# Altium schematic sheet sensors.SchDoc(sensors)
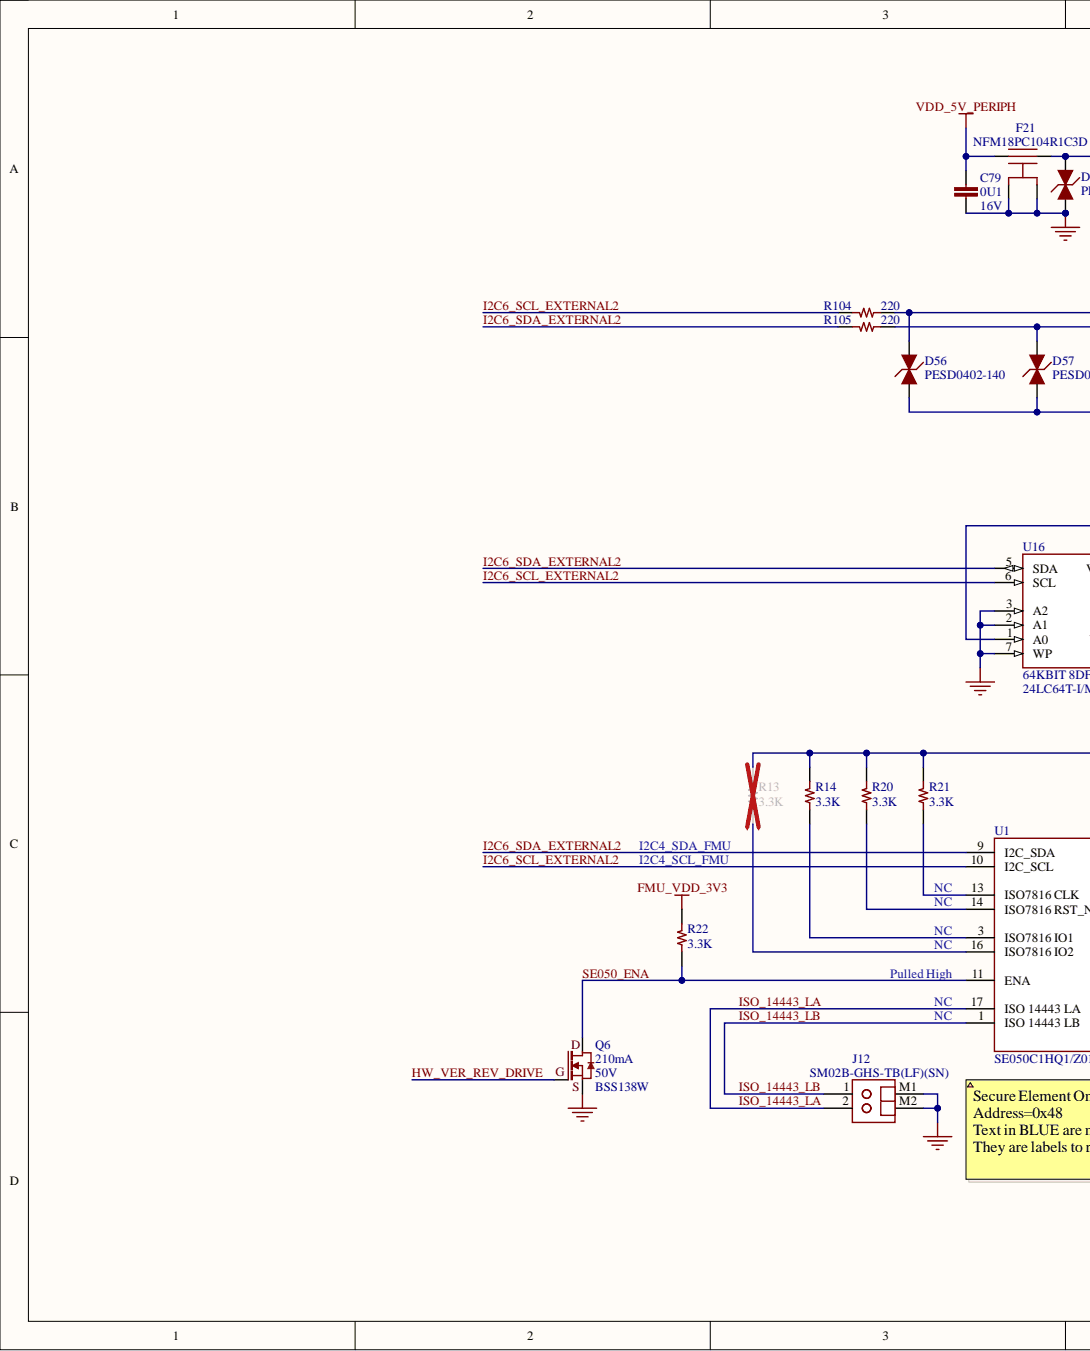
[diagram p0: sensors.SchDoc, left]
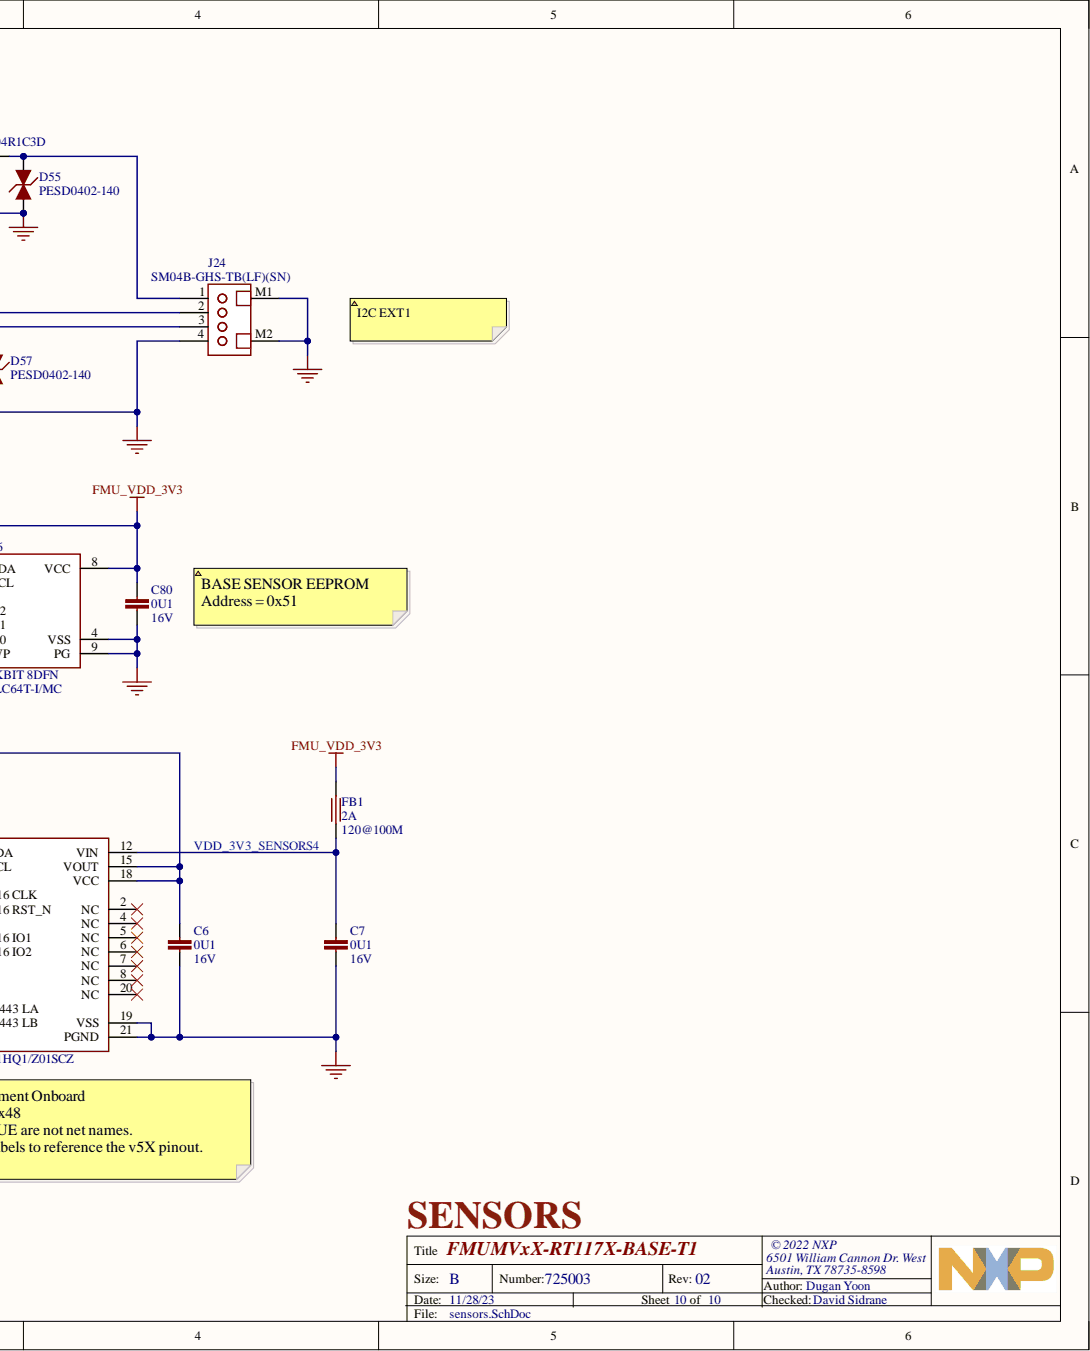
[diagram p1: sensors.SchDoc, right]

## Parts
C6  value 0U1  Voltage - Rated: 16V  [CAPC100X50X56L25N]  (hidden comment: CAP CER 0.1UF 10% 16V X7R 0402)
C7  value 0U1  Voltage - Rated: 16V  [CAPC100X50X56L25N]  (hidden comment: CAP CER 0.1UF 10% 16V X7R 0402)
C79  value 0U1  Voltage - Rated: 16V  [CAPC100X50X56L25N]  (hidden comment: CAP CER 0.1UF 10% 16V X7R 0402)
C80  value 0U1  Voltage - Rated: 16V  [CAPC100X50X56L25N]  (hidden comment: CAP CER 0.1UF 10% 16V X7R 0402)
D55  Manufacturer Part Number: PESD0402-140  [DIONC100X50X43L20N]  (hidden comment: DIODE TVS BIDIRECTIONAL 14VWM 40VC 0402)
D56  Manufacturer Part Number: PESD0402-140  [DIONC100X50X43L20N]  (hidden comment: DIODE TVS BIDIRECTIONAL 14VWM 40VC 0402)
D57  Manufacturer Part Number: PESD0402-140  [DIONC100X50X43L20N]  (hidden comment: DIODE TVS BIDIRECTIONAL 14VWM 40VC 0402)
F21  Manufacturer Part Number: NFM18PC104R1C3D  Voltage - Rated: 16V  [NFM18PC104R1C3_0603_NO_VIA]  (hidden comment: FILTER CAP FEEDTHRU 0.1UF 20% 16V 0603)
FB1  value 120@100M  Current: 2A  [BEADC100X50X55L25]  (hidden comment: IND FERRITE 120@100M 2A 0402)
J12  Manufacturer Part Number: SM02B-GHS-TB(LF)(SN)  [JST_SM02B-GHS-TBLFSN]  (hidden comment: CONN HEADER GH SIDE 2POS 1.25MM SMT)
J24  Manufacturer Part Number: SM04B-GHS-TB(LF)(SN)  [JST_SM04B-GHS-TBLFSN]  (hidden comment: CONN HEADER GH SIDE 4POS 1.25MM SMT)
Q6  Current: 210mA  Manufacturer Part Number: BSS138W  Voltage: 50V  [OnSemi_SC-70-3P65_210X110L20X32N]  (hidden comment: TRANSISTOR N-CH 50V .21A  SC-70)
R13  value 3.3K  [RESC100X50X40L25]  (hidden comment: RES)
R14  value 3.3K  [RESC100X50X40L25]  (hidden comment: RES)
R20  value 3.3K  [RESC100X50X40L25]  (hidden comment: RES)
R21  value 3.3K  [RESC100X50X40L25]  (hidden comment: RES)
R22  value 3.3K  [RESC100X50X40L25]  (hidden comment: RES)
R104  value 220  [RESC100X50X40L25]  (hidden comment: RES SMD 220 OHM 1% 1/16W 0402)
R105  value 220  [RESC100X50X40L25]  (hidden comment: RES SMD 220 OHM 1% 1/16W 0402)
U1  Manufacturer Part Number: SE050C1HQ1/Z01SCZ  [QFN21P40_300X300X33L30X20T190]  (hidden comment: IC IoT Secure Element 20-HX2QFN)
U16  ID: 64KBIT 8DFN  Manufacturer Part Number: 24LC64T-I/MC  [SON9P50_200X300X100L40X25T153X170N]  (hidden comment: IC EEPROM 64K I2C 400KHZ 8DFN)

## Nets
FMU_VDD_3V3: C80.1 FB1.1 R22.1 U16.1(A0) U16.8(VCC)
GND: C6.2 C7.2 C79.2 C80.2 D55.1 D56.1 D57.1 F21.2A F21.2B J12.M1 J12.M2 J24.4 J24.M1 J24.M2 Q6.S U1.19(VSS) U1.21(PGND) U16.2(A1) U16.3(A2) U16.4(VSS) U16.7(WP) U16.9(PG)
VDD_5V_PERIPH: C79.1 F21.1
HW_VER_REV_DRIVE: Q6.G
I2C6_SCL_EXTERNAL2: R104.1 U1.10(I2C_SCL) U16.6(SCL)
I2C6_SDA_EXTERNAL2: R105.1 U1.9(I2C_SDA) U16.5(SDA)
ISO_14443_LA: J12.2 U1.17(ISO_14443_LA)
ISO_14443_LB: J12.1 U1.1(ISO_14443_LB)
SE050_ENA: Q6.D R22.2 U1.11(ENA)
NetC6_1: C6.1 R13.1 R14.1 R20.1 R21.1 U1.15(VOUT) U1.18(VCC)
NetC7_1: C7.1 FB1.2 U1.12(VIN)
NetD55_2: D55.2 F21.3 J24.1
NetD56_2: D56.2 J24.2 R104.2
NetD57_2: D57.2 J24.3 R105.2
NetR13_2: R13.2 U1.16(ISO7816_IO2)
NetR14_2: R14.2 U1.3(ISO7816_IO1)
NetR20_2: R20.2 U1.14
NetR21_2: R21.2 U1.13(ISO7816_CLK)

Not connected: U1 2(NC) 4(NC) 5(NC) 6(NC) 7(NC) 8(NC) 20(NC)

## Library data of the parts
C6 C7 C79 C80 = CAP_0U1_16V_X7R_0402: Manufacturer: Murata Electronics; Manufacturer Part Number: GCM155R71C104KA55J; Category: Capacitors; Component Kind: Standard; ComponentLink1Description: https://www.digikey.com/product-detail/en/murata-electronics/GCM155R71C104KA55J/490-7998-1-ND/4380283; ComponentLink1URL: https://www.digikey.com/product-detail/en/murata-electronics/GCM155R71C104KA55J/490-7998-1-ND/4380283; Description: CAP CER 0.1UF 10% 16V X7R 0402; Operating Temperature: -55°C ~ 125°C; Packaging: Cut Tape (CT); Part Status: Active; Pricing 1: 1=0.1, 10=0.049, 100=0.022, 500=0.0157, 1000=0.01233, 2500=0.01121, 5000=0.01032 (USD); Stock 1: 6381653; Supplier 1: Digi-Key; Supplier Part Number 1: 490-7998-1-ND; Tolerance: ±10%; Library Name: NscDG_Integrated_Library.SchLib
D55-D57 = DIODE_TVS_0402: Manufacturer: Littelfuse Inc.; Category: Circuit Protection; Component Kind: Standard; ComponentLink1Description: https://www.digikey.com/products/en/circuit-protection/tvs-diodes/144?k=PESD0402-140&k=&pkeyword=PESD0402-140&pv7=2&sf=0&quantity=&ColumnSort=0&page=1&pageSize=25; ComponentLink1URL: https://www.digikey.com/products/en/circuit-protection/tvs-diodes/144?k=PESD0402-140&k=&pkeyword=PESD0402-140&pv7=2&sf=0&quantity=&ColumnSort=0&page=1&pageSize=25; Description: DIODE TVS BIDIRECTIONAL 14VWM 40VC 0402; Operating Temperature: -55°C ~ 125°C (TJ); Packaging: Cut Tape (CT); Part Status: Active; Pricing 1: 10000=0.13154, 30000=0.12096 (USD); Stock 1: 281442; Supplier 1: Digi-Key; Supplier Part Number 1: PESD0402-140CT-ND; Library Name: NscDG_Integrated_Library.SchLib
F21 = FILTER_0.1uF_16V_2A_NO_VIA_0603: Manufacturer: Murata Electronics North America; Category: Filters; Component Kind: Standard; ComponentLink1Description: https://www.digikey.com/products/en/filters/feed-through-capacitors/845?k=NFM18PC104R1C3D&k=&pkeyword=NFM18PC104R1C3D&pv7=2&sf=0&quantity=&ColumnSort=0&page=1&pageSize=25; ComponentLink1URL: https://www.digikey.com/products/en/filters/feed-through-capacitors/845?k=NFM18PC104R1C3D&k=&pkeyword=NFM18PC104R1C3D&pv7=2&sf=0&quantity=&ColumnSort=0&page=1&pageSize=25; Description: FILTER CAP FEEDTHRU 0.1UF 20% 16V 0603; Operating Temperature: -55°C ~ 125°C; Packaging: Cut Tape (CT); Part Status: Active; Pricing 1: 1=0.19, 10=0.173, 25=0.1652, 50=0.1598, 100=0.1544, 250=0.1464, 500=0.12246, 1000=0.09503 (USD); Stock 1: 172860; Supplier 1: Digi-Key; Supplier Part Number 1: 490-6969-1-ND; Tolerance: ±20%; Library Name: NscDG_Integrated_Library.SchLib
FB1 = IND_FERRITE_120@120M_2A_0402: Manufacturer: Murata Electronics North America; Manufacturer Part Number: BLM15PX121SN1D; Category: Inductors; Component Kind: Standard; ComponentLink1Description: https://www.digikey.com/product-detail/en/murata-electronics/BLM15PX121SN1D/490-9656-1-ND/4934752; ComponentLink1URL: https://www.digikey.com/product-detail/en/murata-electronics/BLM15PX121SN1D/490-9656-1-ND/4934752; Description: IND FERRITE 120@100M 2A 0402; Operating Temperature: -55°C ~ 125°C; Packaging: Cut Tape (CT); Part Status: Active; Pricing 1: 1=0.10 (USD); Stock 1: 98122; Supplier 1: Digi-Key; Supplier Part Number 1: 490-9656-1-ND; Library Name: NscDG_Integrated_Library.SchLib
J12 = CONN_JST_GH_2PIN_RA_SMT: Manufacturer: JST Sales America Inc.; System: Connector; Voltage - Rated: 50V; Category: Connectors, Interconnects; Component Kind: Standard; ComponentLink1Description: https://www.digikey.com/products/en/connectors-interconnects/rectangular-connectors-headers-male-pins/314?k=sm02b&k=&pkeyword=sm02b&sv=0&pv7=2&s=2395&pv1989=0&sf=0&FV=ffe0013a&quantity=&ColumnSort=0&page=1&pageSize=25; ComponentLink1URL: https://www.digikey.com/products/en/connectors-interconnects/rectangular-connectors-headers-male-pins/314?k=sm02b&k=&pkeyword=sm02b&sv=0&pv7=2&s=2395&pv1989=0&sf=0&FV=ffe0013a&quantity=&ColumnSort=0&page=1&pageSize=25; Description: CONN HEADER GH SIDE 2POS 1.25MM SMT; Operating Temperature: -25°C ~ 85°C; Packaging: Cut Tape (CT); Part Status: Active; Pricing 1: 1=0.44, 10=0.413, 100=0.316, 500=0.27502, 1000=0.22689 (USD); Stock 1: 90823; Supplier 1: Digi-Key; Supplier Part Number 1: 455-1564-1-ND; Library Name: NscDG_Integrated_Library.SchLib
J24 = CONN_JST_GH_4PIN_RA_SMT: Manufacturer: JST Sales America Inc.; System: Connector; Voltage Rating: 50V; Category: Connectors, Interconnects; Component Kind: Standard; ComponentLink1Description: https://www.digikey.com/products/en/connectors-interconnects/rectangular-connectors-headers-male-pins/314?k=SM04B-GHS-TB%28LF%29%28SN%29-&k=&pkeyword=SM04B-GHS-TB%28LF%29%28SN%29-&pv7=2&sf=0&quantity=&ColumnSort=0&page=1&pageSize=25; ComponentLink1URL: https://www.digikey.com/products/en/connectors-interconnects/rectangular-connectors-headers-male-pins/314?k=SM04B-GHS-TB%28LF%29%28SN%29-&k=&pkeyword=SM04B-GHS-TB%28LF%29%28SN%29-&pv7=2&sf=0&quantity=&ColumnSort=0&page=1&pageSize=25; Description: CONN HEADER GH SIDE 4POS 1.25MM SMT; Operating Temperature: -25°C ~ 85°C; Packaging: Cut Tape (CT); Part Status: Active; Pricing 1: 1=0.45, 10=0.423, 100=0.3244, 500=0.28212, 1000=0.23274 (USD); Stock 1: 109892; Supplier 1: Digi-Key; Supplier Part Number 1: 455-1566-1-ND; Library Name: NscDG_Integrated_Library.SchLib
Q6 = TRAN_MOSFET_N-CH_BSS138W_SMT: Manufacturer: ON Semiconductor; Category: Discrete Semiconductor Products; Component Kind: Standard; ComponentLink1Description: https://www.digikey.com/product-detail/en/on-semiconductor/BSS138W/BSS138WCT-ND/3907886; ComponentLink1URL: https://www.digikey.com/product-detail/en/on-semiconductor/BSS138W/BSS138WCT-ND/3907886; Description: TRANSISTOR N-CH 50V .21A  SC-70; Packaging: Cut Tape (CT); Part Status: Active; Pricing 1: 1=0.43 (USD); Stock 1: 2599; Supplier 1: Digi-Key; Supplier Part Number 1: BSS138WCT-ND; Library Name: NscDG_Integrated_Library.SchLib
R13 R14 R20-R22 = RC0402FR-073K3P: RES SMD 3.3K OHM 1% 1/16W 0402; Manufacturer: Yageo; Database Table Name: VergeLibrary; Category: Resistor; Component Kind: Standard; ComponentLink1Description: Supplier Page; ComponentLink1URL: https://www.digikey.com/product-detail/en/yageo/RC0402FR-073K3P/YAG1267CT-ND/4935382; Library Name: VergeLibrary.DbLib; Manufacturer Part Number: RC0402FR-073K3P; Operating Temperature: -55°C ~ 155°C; Part Number: RC0402FR-073K3P; Power: 1/16W; Supplier 1: Digi-Key; Supplier Part Number 1: YAG1267CT-ND; Tolerance: ±1%
R104 R105 = RES_220_1%_1/16W_0402: Manufacturer: Yageo; Manufacturer Part Number: RC0402FR-07220RL; Category: Resistors; Component Kind: Standard; ComponentLink1Description: https://www.digikey.com/products/en/resistors/chip-resistor-surface-mount/52?k=RC0402FR-07220RL&k=&pkeyword=RC0402FR-07220RL&pv7=2&sf=0&quantity=&ColumnSort=0&page=1&pageSize=25; ComponentLink1URL: https://www.digikey.com/products/en/resistors/chip-resistor-surface-mount/52?k=RC0402FR-07220RL&k=&pkeyword=RC0402FR-07220RL&pv7=2&sf=0&quantity=&ColumnSort=0&page=1&pageSize=25; Description: RES SMD 220 OHM 1% 1/16W 0402; Operating Temperature: -55°C ~ 155°C; Packaging: Cut Tape (CT); Part Status: Active; Power: 1/16W; Pricing 1: 1=0.1, 10=0.014, 100=0.0056, 1000=0.00253, 2500=0.0022, 5000=0.00181 (USD); Stock 1: 897942; Supplier 1: Digi-Key; Supplier Part Number 1: 311-220LRCT-ND; Tolerance: ±1%; Library Name: NscDG_Integrated_Library.SchLib
U1 = IC_SE050C1HQ1: Manufacturer: NXP USA Inc.; Category: Integrated Circuits (ICs); Component Kind: Standard; ComponentLink1Description: https://www.digikey.com/products/en/integrated-circuits-ics/specialized-ics/686?k=SE050C1HQ1%2FZ01SCZ&k=&pkeyword=SE050C1HQ1%2FZ01SCZ&sv=0&pv7=2&sf=0&quantity=&ColumnSort=0&page=1&pageSize=25; ComponentLink1URL: https://www.digikey.com/products/en/integrated-circuits-ics/specialized-ics/686?k=SE050C1HQ1%2FZ01SCZ&k=&pkeyword=SE050C1HQ1%2FZ01SCZ&sv=0&pv7=2&sf=0&quantity=&ColumnSort=0&page=1&pageSize=25; Description: IC IoT Secure Element 20-HX2QFN; Max Operating Temperature: 85°C; Min Operating Temperature: -25°C; Packaging: Cut Tape (CT); Pricing 1: 1=4.09 (USD); Stock 1: 1530; Supplier 1: Digi-Key; Supplier Part Number 1: 568-15377-1-ND; Library Name: NscDG_Integrated_Library.SchLib
U16 = IC_EEPROM_64K_I2C_8DFN: Manufacturer: Microchip Technology; Category: Integrated Circuits (ICs); Component Kind: Standard; ComponentLink1Description: https://www.digikey.com/products/en/integrated-circuits-ics/memory/774?k=&pkeyword=&sv=0&pv7=2&sf=0&FV=fffc0096&mpart=24LC64T-I%2FMC&quantity=&ColumnSort=0&page=1&pageSize=25; ComponentLink1URL: https://www.digikey.com/products/en/integrated-circuits-ics/memory/774?k=&pkeyword=&sv=0&pv7=2&sf=0&FV=fffc0096&mpart=24LC64T-I%2FMC&quantity=&ColumnSort=0&page=1&pageSize=25; Description: IC EEPROM 64K I2C 400KHZ 8DFN; Operating Temperature: -40°C ~ 85°C (TA); Packaging: Cut Tape (CT); Part Status: Active; Pricing 1: 1=0.47 (USD); Stock 1: 2351; Supplier 1: Digi-Key; Supplier Part Number 1: 24LC64T-I/MCCT-ND; Library Name: NscDG_Integrated_Library.SchLib
